# Altium schematic sheet Sheet_CPU.SchDoc
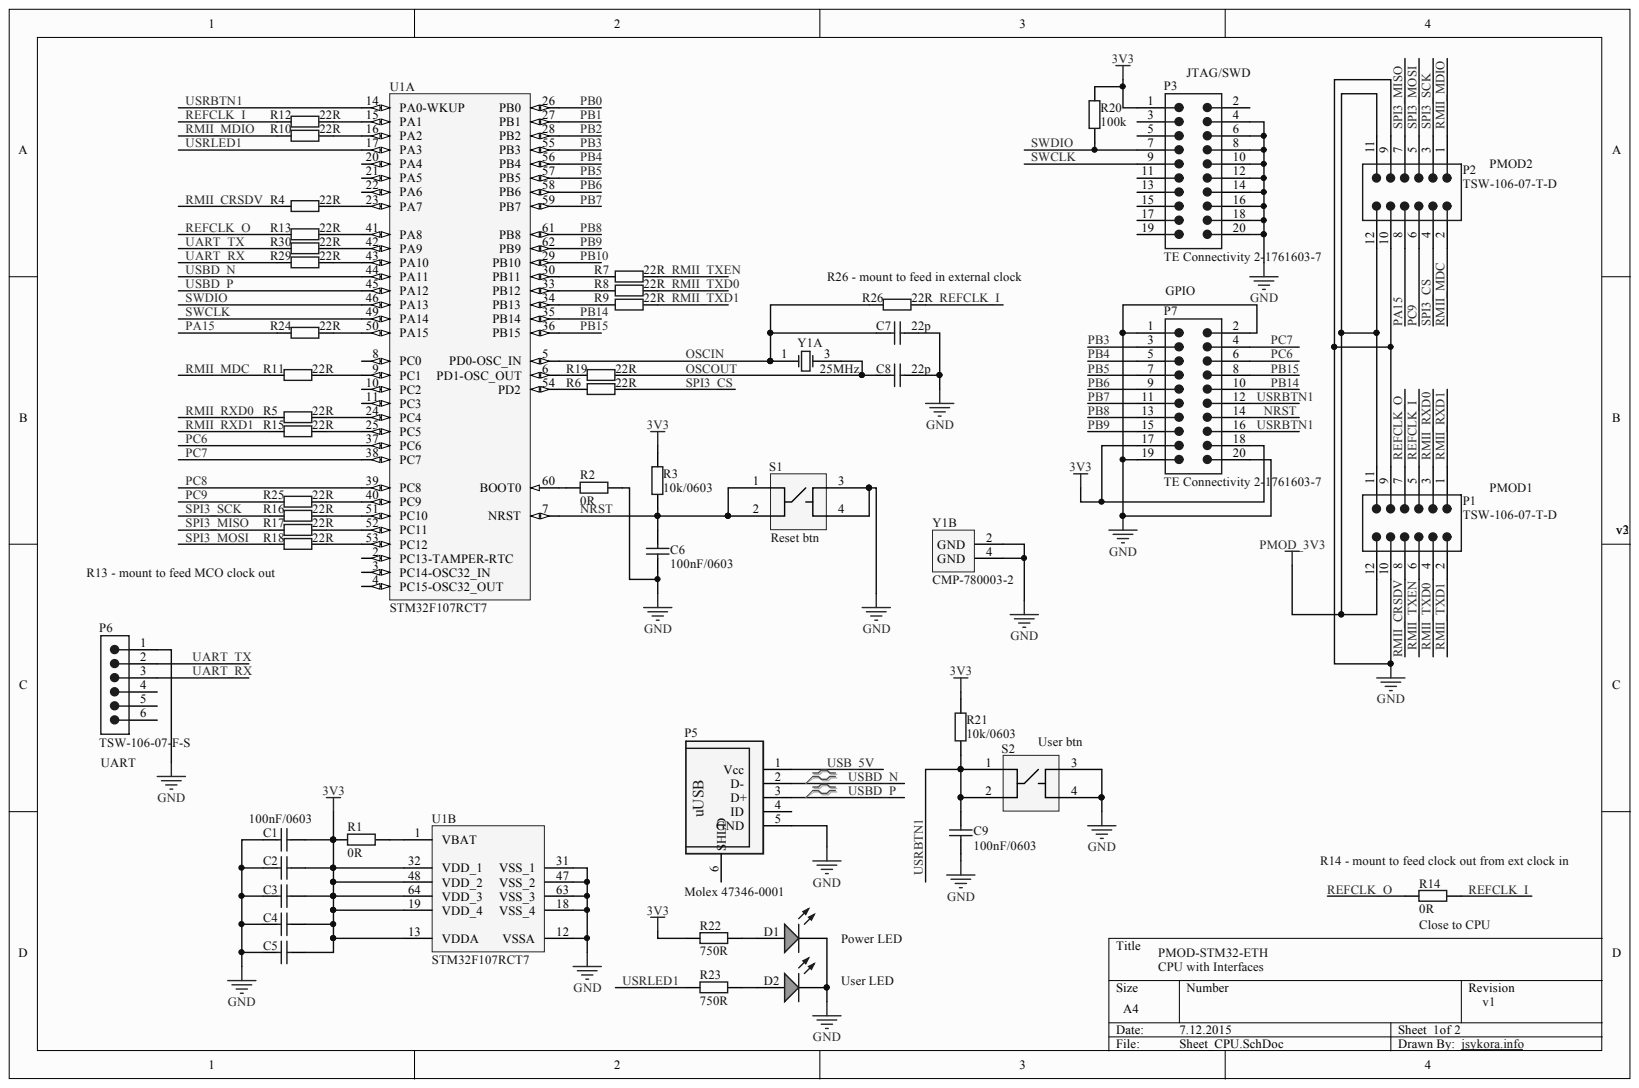
[diagram p0: Sheet_CPU.SchDoc]

## Parts
C1  100nF/0603  [CAPC1608X90X35ML10T15]
C2  (no value)  [CAPC1608X90X35ML10T15]
C3  (no value)  [CAPC1608X90X35ML10T15]
C4  (no value)  [CAPC1608X90X35ML10T15]
C5  (no value)  [CAPC1608X90X35ML10T15]
C6  100nF/0603  [CAPC1608X90X35ML10T15]
C7  22p  [CAPC1608X90X35ML15T15]
C8  22p  [CAPC1608X90X35ML15T15]
C9  100nF/0603  [CAPC1608X90X35ML10T15]
D1  (no value)  [SMD_LED0805]
D2  (no value)  [SMD_LED0805]
P1  TSW-106-07-T-D  [SMTC-TSW-106-07-X-D]
P2  TSW-106-07-T-D  [SMTC-TSW-106-07-X-D]
P3  TE Connectivity 2-1761603-7  Manufacturer Part Number: 2-1761603-7  [20 pin 2.54mm th header]
P5  Molex 47346-0001  Manufacturer: Molex  [PCB-777797-1]
P6  TSW-106-07-F-S  [SMTC-TSW-106-07-X-S]
P7  TE Connectivity 2-1761603-7  Manufacturer Part Number: 2-1761603-7  [20 pin 2.54mm th header]
R1  0R  [RESC1608X55X25ML10T15]
R2  0R  [RESC1608X55X25ML10T15]
R3  10k/0603  [RESC1609X55X30ML10T20]
R4  22R  [RESC1609X50X30ML10T20]
R5  22R  [RESC1609X50X30ML10T20]
R6  22R  [RESC1609X50X30ML10T20]
R7  22R  [RESC1609X50X30ML10T20]
R8  22R  [RESC1609X50X30ML10T20]
R9  22R  [RESC1609X50X30ML10T20]
R10  22R  [RESC1609X50X30ML10T20]
R11  22R  [RESC1609X50X30ML10T20]
R12  22R  [RESC1609X50X30ML10T20]
R13  22R  [RESC1609X50X30ML10T20]
R14  0R  [RESC1608X55X25ML10T15]
R15  22R  [RESC1609X50X30ML10T20]
R16  22R  [RESC1609X50X30ML10T20]
R17  22R  [RESC1609X50X30ML10T20]
R18  22R  [RESC1609X50X30ML10T20]
R19  22R  [RESC1609X50X30ML10T20]
R20  100k  [RESC1609X50X30ML10T20]
R21  10k/0603  [RESC1609X55X30ML10T20]
R22  750R  [RESC1609X50X30ML10T20]
R23  750R  [RESC1609X50X30ML10T20]
R24  22R  [RESC1609X50X30ML10T20]
R25  22R  [RESC1609X50X30ML10T20]
R26  22R  [RESC1609X50X30ML10T20]
R29  22R  [RESC1609X50X30ML10T20]
R30  22R  [RESC1609X50X30ML10T20]
S1  (no value)  [PCB-1274972-1]
S2  (no value)  [PCB-1274972-1]
U1  STM32F107RCT7  [STM-LQFP64_N]  (parts A,B)
Y1  25MHz  [ABRA-ABM3B-4_V]  (parts A,B)

## Nets
3V3: C1.2 C2.2 C3.2 C4.2 C5.2 P3.1 P7.17 P7.18 R1.1 R3.2 R20.2 R21.2 R22.1 U1.13(VDDA) U1.19(VDD_4) U1.32(VDD_1) U1.48(VDD_2) U1.64(VDD_3)
GND: C1.1 C2.1 C3.1 C4.1 C5.1 C6.1 C7.2 C8.2 C9.1 D1.1 D2.1 P1.9 P1.10 P2.9 P2.10 P3.4 P3.6 P3.8 P3.10 P3.12 P3.14 P3.16 P3.18 P3.20 P5.5(GND) P6.1 P7.1 P7.2 P7.19 P7.20 R2.2 S1.3 S1.4 S2.3 S2.4 U1.12(VSSA) U1.18(VSS_4) U1.31(VSS_1) U1.47(VSS_2) U1.63(VSS_3) Y1.2(GND) Y1.4(GND)
PMOD_3V3: P1.11 P1.12 P2.11 P2.12
NRST: C6.2 P7.14 R3.1 S1.1 S1.2 U1.7(NRST)
OSCIN: C7.1 R26.1 U1.5(PD0-OSC_IN) Y1.1
OSCOUT: C8.1 R19.2 Y1.3
PA15: P2.8 R24.1
PB0: U1.26(PB0)
PB1: U1.27(PB1)
PB2: U1.28(PB2)
PB3: P7.3 U1.55(PB3)
PB4: P7.5 U1.56(PB4)
PB5: P7.7 U1.57(PB5)
PB6: P7.9 U1.58(PB6)
PB7: P7.11 U1.59(PB7)
PB8: P7.13 U1.61(PB8)
PB9: P7.15 U1.62(PB9)
PB10: U1.29(PB10)
PB14: P7.10 U1.35(PB14)
PB15: P7.8 U1.36(PB15)
PC6: P7.6 U1.37(PC6)
PC7: P7.4 U1.38(PC7)
PC8: U1.39(PC8)
PC9: P2.6 R25.1
REFCLK_I: P1.5 R12.1 R14.2 R26.2
REFCLK_O: P1.7 R13.1 R14.1
RMII_CRSDV: P1.8 R4.1
RMII_MDC: P2.2 R11.1
RMII_MDIO: P2.1 R10.1
RMII_RXD0: P1.3 R5.1
RMII_RXD1: P1.1 R15.1
RMII_TXD0: P1.4 R8.2
RMII_TXD1: P1.2 R9.2
RMII_TXEN: P1.6 R7.2
SPI3_CS: P2.4 R6.2
SPI3_MISO: P2.7 R17.1
SPI3_MOSI: P2.5 R18.1
SPI3_SCK: P2.3 R16.1
SWCLK: P3.9 U1.49(PA14)
SWDIO: P3.7 R20.1 U1.46(PA13)
UART_RX: P6.3 R29.1
UART_TX: P6.2 R30.1
USB_5V: P5.1(Vcc)
USBD_N: P5.2(D-) U1.44(PA11)
USBD_P: P5.3(D+) U1.45(PA12)
USRBTN1: C9.2 P7.12 P7.16 R21.1 S2.1 S2.2 U1.14(PA0-WKUP)
USRLED1: R23.1 U1.17(PA3)
NetD1_2: D1.2 R22.2
NetD2_2: D2.2 R23.2
NetR1_2: R1.2 U1.1(VBAT)
NetR2_1: R2.1 U1.60(BOOT0)
NetR4_2: R4.2 U1.23(PA7)
NetR5_2: R5.2 U1.24(PC4)
NetR6_1: R6.1 U1.54(PD2)
NetR7_1: R7.1 U1.30(PB11)
NetR8_1: R8.1 U1.33(PB12)
NetR9_1: R9.1 U1.34(PB13)
NetR10_2: R10.2 U1.16(PA2)
NetR11_2: R11.2 U1.9(PC1)
NetR12_2: R12.2 U1.15(PA1)
NetR13_2: R13.2 U1.41(PA8)
NetR15_2: R15.2 U1.25(PC5)
NetR16_2: R16.2 U1.51(PC10)
NetR17_2: R17.2 U1.52(PC11)
NetR18_2: R18.2 U1.53(PC12)
NetR19_1: R19.1 U1.6(PD1-OSC_OUT)
NetR24_2: R24.2 U1.50(PA15)
NetR25_2: R25.2 U1.40(PC9)
NetR29_2: R29.2 U1.43(PA10)
NetR30_2: R30.2 U1.42(PA9)

Not connected: P3 2 3 5 11 13 15 17 19; P5 4(ID) 6(SHLD); P6 4 5 6; U1 2(PC13-TAMPER-RTC) 3(PC14-OSC32_IN) 4(PC15-OSC32_OUT) 8(PC0) 10(PC2) 11(PC3) 20(PA4) 21(PA5) 22(PA6)

## Library data of the parts
C1 C6 C9 = GRM188R71E104KA01D: CAP CER 0.1UF 25V 10% X7R 0603; Capacitance: 1e-07 F; Case/Package (SI): 1608; Lead-Free Status: Lead Free; Voltage Rating (DC): 25.0 V; Capacitance Tolerance: ±10%; Component Kind: Standard; Dielectric Characteristic: X7R; Library Name: Octopart library; Lifecycle Status: Active; Mounting Style: Surface Mount; Number of Pins: 2; OctopartID: 5872917a839b87b5; Octopart Url: https://octopart.com/grm188r71e104ka01d-murata-196239; Packaging: Cut Tape (CT); REACH SVHC Compliance: No SVHC; RoHS: Compliant; Size-Height: 0.0008 m; Size-Length: 0.0016 m; Size-Thickness: 0.0008 m; Size-Width: 0.0008 m
C2-C5 = CMP-19299717-3: CAP CER 0.1UF 25V 10% X7R 0603; Capacitance: 1e-07 F; Case/Package (SI): 1608; Lead-Free Status: Lead Free; Voltage Rating (DC): 25.0 V; Capacitance Tolerance: ±10%; Component Kind: Standard; Dielectric Characteristic: X7R; Library Name: CommunityVault; Lifecycle Status: Active; Mounting Style: Surface Mount; Number of Pins: 2; OctopartID: 5872917a839b87b5; Octopart Url: https://octopart.com/grm188r71e104ka01d-murata-196239; Packaging: Cut Tape (CT); REACH SVHC Compliance: No SVHC; RoHS: Compliant; Size-Height: 0.0008 m; Size-Length: 0.0016 m; Size-Thickness: 0.0008 m; Size-Width: 0.0008 m
C7 C8 = 06035A220KAT2A: CAP CER 22PF 50V 10% NP0 0603; Capacitance: 2.2e-11 F; Dielectric Characteristic: C0G/NP0; Lead-Free Status: Lead Free; Voltage Rating (DC): 50.0 V; Capacitance Tolerance: ±10%; Case/Package: 0603; Component Kind: Standard; Library Name: Octopart library; Mounting Style: Surface Mount; Number of Pins: 2; OctopartID: d69e1fb503810959; Octopart Url: https://octopart.com/06035a220kat2a-avx+interconnect+%2F+elco-2224249; Packaging: Cut Tape (CT); REACH SVHC Compliance: No SVHC; RoHS: Compliant
D1 D2 = CMP-1544858-3: LED GREEN CLEAR THIN 0805 SMD; Case/Package (SI): 2012; Forward Voltage: 2.1 V; Lead-Free Status: Lead Free; Lens Type: Non-Diffused; Luminous Intensity: 0.0045 cd; Viewing Angle: 130 °; Wavelength: 5.85e-07 m; Case/Package: 0805; Color: Green; Component Kind: Standard; Library Name: CommunityVault; OctopartID: 3aeff3ed7c74e528; Octopart Url: https://octopart.com/ltst-c171gkt-lite-on-549885; Packaging: Tape & Reel (TR); RoHS: Compliant; Size-Height: 0.0008 m; Size-Length: 0.002 m; Size-Width: 0.00125 m
P1 P2 = TSW-106-07-T-D: 0.025" SQ Post Header, Through-hole, Vertical, -55 to 105 degC, 2.54 mm Pitch, 12-Pin, Male, RoHS; Lead-Free Status: Lead Free; Number of Contacts: 12; Pin Pitch: 0.00254 m; Component Kind: Standard; Contact Material: Phosphor Bronze; Contact Plating: Tin; Gender: Male; Housing Color: Black; Housing Material: Plastic; Library Name: Octopart library; Mounting Style: Through Hole; Number of Positions: 12; Number of Rows: 2; OctopartID: 3529fc27380ceaee; Octopart Url: https://octopart.com/tsw-106-07-t-d-samtec-272451; REACH SVHC Compliance: No SVHC; RoHS: Compliant; Size-Height: 0.00254 m; Size-Length: 0.01524 m; Size-Width: 0.00508 m
P3 P7 = CMP-9c1f57d0187043d8-1: Connector Ejector Header 20 Position 2.54mm Solder Straight Through Hole; Current Rating: 1.0 A; ELV Compliant: Compliant; Gender: Male; Lead-Free Status: Lead Free; Number of Contacts: 20; Number of Mating Cycles: 200; Pin Pitch: 0.00254 m; Color: Blue; Component Kind: Standard; Contact Material: Phosphor Bronze; Contact Plating: Tin, Tin over Nickel, Gold; Flammability Rating: UL 94 V-0; Housing Color: Blue; Housing Material: Thermoplastic; Library Name: CommunityVault; Lifecycle Status: Active; Mounting Style: PC Board, Through Hole, PCB; Number of Rows: 2; OctopartID: 9c1f57d0187043d8; Octopart URL: http://octopart.com/2-1761603-7-te+connectivity-46506249; Orientation: Vertical; Packaging: Box; REACH SVHC Compliance: No SVHC; RoHS: Compliant
P5 = 47346-0001: MOLEX   47346-0001   MICRO USB TYPE B, RECEPTACLE, BOTTOM; Contact Resistance: 0.04 Ω; Current Rating: 0.5 A; Gender: Female; Glow Wire Compliant: Non-Compliant; Number of Circuits: 5; Pin Pitch: 0.00065 m; Voltage Rating (AC): 100.0 V; Component Kind: Standard; Contact Material: Copper Alloy; Contact Plating: Gold; Halogen Free Status: Low Halogen; Library Name: Octopart library; Lifecycle Status: Active; Manufacturer Part Number: 47346-0001; Number of Contacts: 5; Number of Positions: 5; Number of Rows: 1; OctopartID: 20ade9ca51cbff6a; Octopart Url: https://octopart.com/47346-0001-molex-7164928; Orientation: Right Angle; RoHS: Compliant
P6 = TSW-106-07-F-S: 0.025" SQ Post Header, Through-hole, Vertical, -55 to 125 degC, 2.54 mm Pitch, 6-Pin, Male, RoHS; Number of Contacts: 6; Pin Pitch: 0.00254 m; Component Kind: Standard; Contact Material: Phosphor Bronze; Contact Plating: Gold; Gender: Male; Library Name: Octopart library; Mounting Style: Through Hole; Number of Rows: 1; OctopartID: 34dafd4d4794eb1d; Octopart Url: https://octopart.com/tsw-106-07-f-s-samtec-10311208; REACH SVHC Compliance: No SVHC; RoHS: Compliant
R1 R2 R14 = CMP-3661350-2: Thick Film Resistors - SMD ZERO OHM JUMPER; Lead-Free Status: Lead Free; Power Rating: 0.1 W; Resistance: 0.0 Ω; Resistance Tolerance: ±5%; Voltage Rating (DC): 50.0 V; Case/Package: 0603; Component Kind: Standard; Library Name: CommunityVault; Mounting Style: Surface Mount; Number of Pins: 2; OctopartID: ee3b27bc163331fc; Octopart Url: https://octopart.com/rc0603jr-130rl-yageo-9297262; RoHS: Compliant; Size-Length: 0.0016 m
R3 R21 = CMP-1863099-2: RES SMD 10K OHM 0.1% 1/10W 0603; Lead-Free Status: Lead Free; Power Rating: 0.1 W; Resistance: 10000.0 Ω; Temperature Coefficient: 25.0 ppm/°C; Voltage Rating (DC): 50.0 V; Case/Package: 0603; Component Kind: Standard; Library Name: CommunityVault; Number of Pins: 2; OctopartID: e5122ede4c283ad5; Octopart Url: https://octopart.com/tnpw060310k0beea-vishay-46566492; Resistance Tolerance: ±0.1%; RoHS: Compliant
R4-R13 R15-R19 R24-R26 R29 R30 = CMP-1857179-2: Res Thick Film 0603 22 Ohm 1% 1/10W ±100ppm/C Molded SMD SMD Paper T/R; Lead-Free Status: Lead Free; Power Rating: 0.1 W; Resistance: 22.0 Ω; Voltage Rating (DC): 75.0 V; Case/Package: 0603; Component Kind: Standard; Library Name: CommunityVault; Mounting Style: Surface Mount; Number of Pins: 2; OctopartID: c28a09e0a6061d4d; Octopart Url: https://octopart.com/crcw060322r0fkea-vishay-39862762; REACH SVHC Compliance: No SVHC; Resistance Tolerance: ±1%; RoHS: Compliant; Size-Height: 0.00045 m; Size-Length: 0.00155 m; Size-Width: 0.00085 m
R20 = CMP-1855988-2: RES SMD 100K OHM 5% 1/10W 0603; Lead-Free Status: Lead Free; Power Rating: 0.1 W; Resistance: 100000.0 Ω; Temperature Coefficient: 200.0 ppm/°C; Voltage Rating (DC): 75.0 V; Case/Package: 0603; Component Kind: Standard; Library Name: CommunityVault; Mounting Style: Surface Mount; Number of Pins: 2; OctopartID: 928159d1bace4d46; Octopart Url: https://octopart.com/crcw0603100kjnea-vishay-39456041; Packaging: Cut Tape (CT); REACH SVHC Compliance: No SVHC; Resistance Tolerance: ±5%; RoHS: Compliant; Size-Height: 0.00045 m; Size-Length: 0.00155 m; Size-Width: 0.00085 m
R22 R23 = CMP-3942184-2: RES SMD 750 OHM 1% 1/10W 0603; Lead-Free Status: Lead Free; Power Rating: 0.1 W; Resistance: 750.0 Ω; Temperature Coefficient: 100.0 ppm/°C; Voltage Rating (DC): 75.0 V; Case/Package: 0603; Component Kind: Standard; Library Name: CommunityVault; Mounting Style: Surface Mount; Number of Pins: 2; OctopartID: 5c1c974b858b83db; Octopart Url: https://octopart.com/crcw0603750rfkea-vishay-39714033; REACH SVHC Compliance: No SVHC; Resistance Tolerance: ±1%; RoHS: Compliant; Size-Height: 0.00045 m; Size-Length: 0.00155 m; Size-Width: 0.00085 m
S1 S2 = CMP-1274972-2: SWITCH TACTILE SPST-NO 0.05A 24V; Contacts Type: SPST; ELV Compliant: Compliant; Lead-Free Status: Lead Free; Component Kind: Standard; Contact Current Rating: 0.05 A; Contact Material: Copper Alloy; Contact Plating: Silver; Library Name: CommunityVault; Lifecycle Status: Active; Mounting Style: Surface Mount; OctopartID: 00c5cb91b7abdf02; Octopart Url: https://octopart.com/fsm4jsmatr-te+connectivity+%2F+alcoswitch-39752200; Packaging: Tape & Reel (TR); REACH SVHC Compliance: No SVHC; RoHS: Compliant
U1 = STM32F107RCT7: ARM Cortex-M3 32-bit MCU, 256 KB Flash, 64 KB Internal RAM, 51 I/Os, 64-pin LQFP, -40 to 105 degC, Tray; Clock Speed: 72000000.0 Hz; RAM Memory Size: 65536 B; Component Kind: Standard; Library Name: Octopart library; Lifecycle Status: Active; Number of Pins: 64; OctopartID: 6e4da6048e89b18a; Octopart Url: https://octopart.com/stm32f107rct7-stmicroelectronics-21218722; REACH SVHC Compliance: No SVHC; RoHS: Compliant
Y1 = CMP-780003-2: Crystal, SMD, 25.000000MHz Stab=50ppm 18.0pF; Equivalent Series Resistance (ESR): 50.0 Ω; Frequency: 25000000.0 Hz; Lead-Free Status: Lead Free; Load Capacitance: 1.8e-11 F; Component Kind: Standard; Library Name: CommunityVault; Mounting Style: Surface Mount; Number of Pins: 4; OctopartID: 3da4f4aac847e263; Octopart Url: https://octopart.com/abm3b-25.000mhz-b2-t-abracon-315525; REACH SVHC Compliance: No SVHC; RoHS: Compliant; Size-Height: 0.0011 m; Size-Length: 0.005 m; Size-Width: 0.0032 m
